# Altium schematic sheet cwh_psu.SchDoc
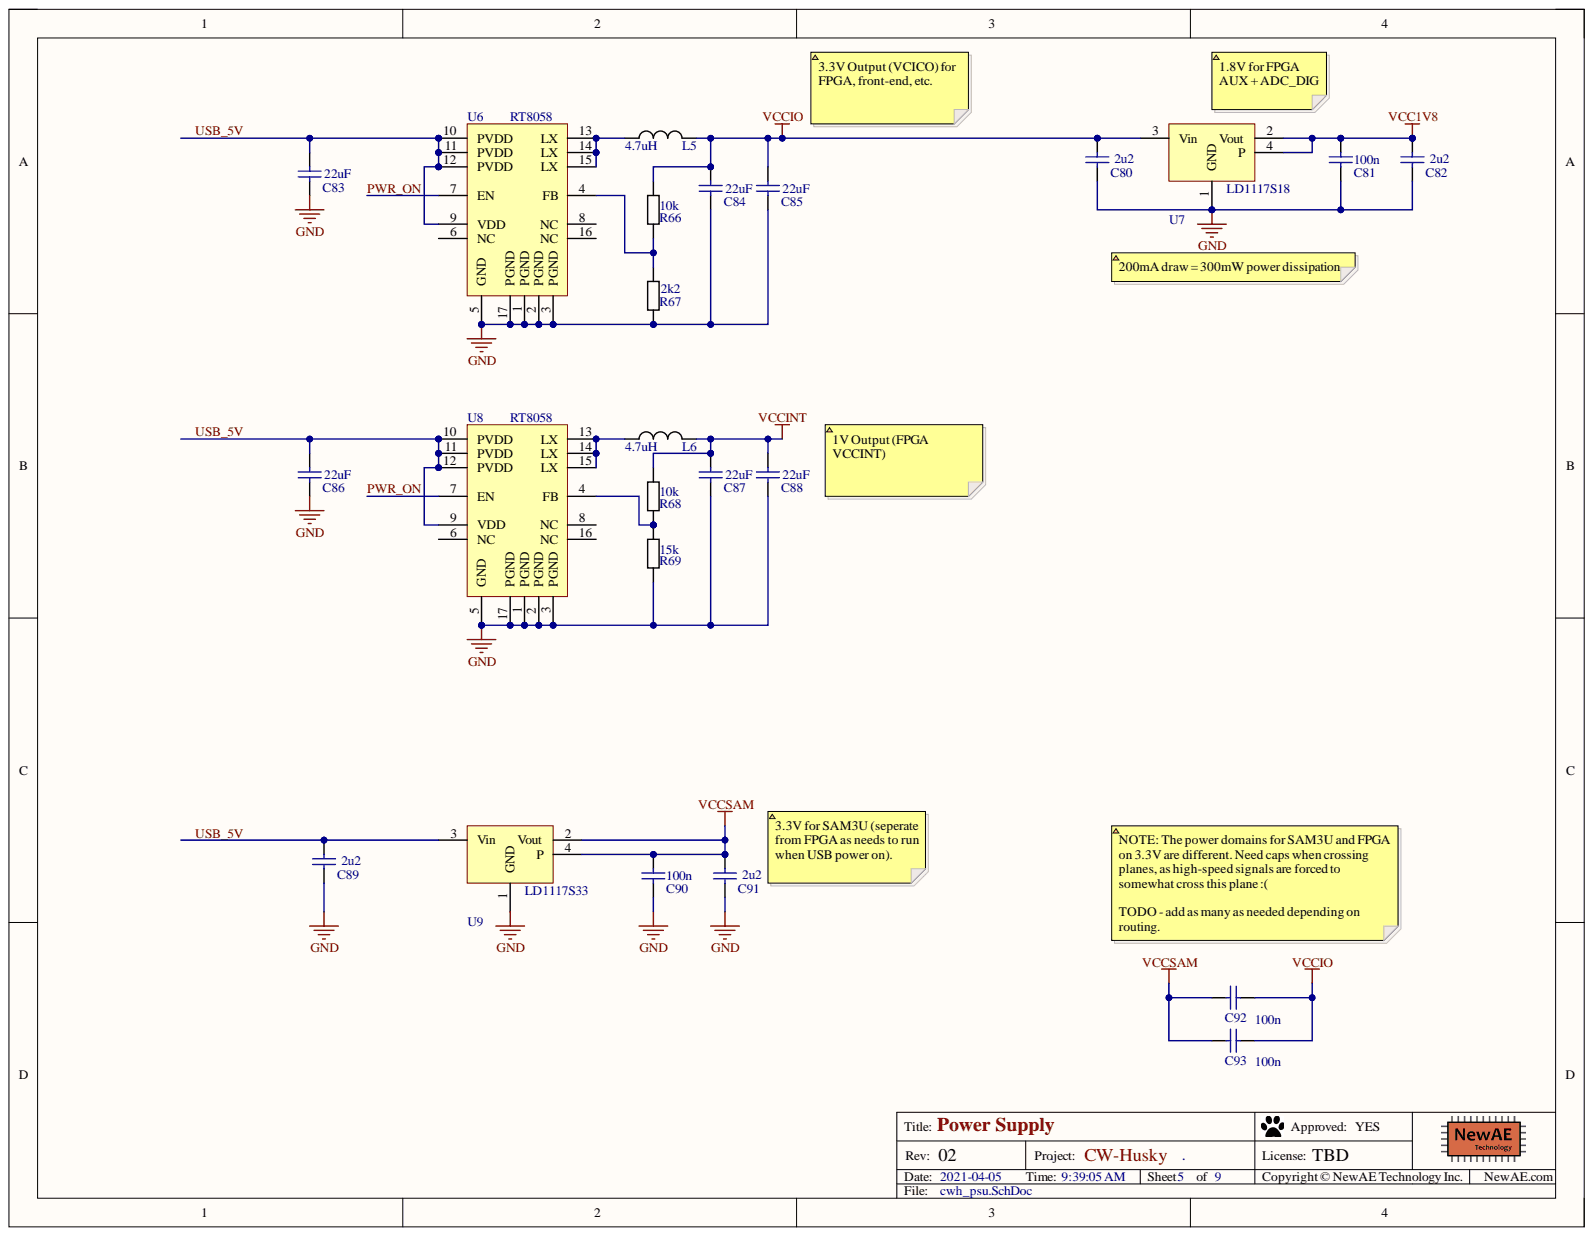
[diagram p0: cwh_psu.SchDoc]

## Parts
C80  2u2  [0603]
C81  100n  [0402]
C82  2u2  [0603]
C83  22uF  [0805]
C84  22uF  [0805]
C85  22uF  [0805]
C86  22uF  [0805]
C87  22uF  [0805]
C88  22uF  [0805]
C89  2u2  [0603]
C90  100n  [0402]
C91  2u2  [0603]
C92  100n  [0603]
C93  100n  [0603]
L5  4.7uH  [SMD,6x6mm]
L6  4.7uH  [SMD,6x6mm]
R66  10k  [0603]
R67  2k2  [0603]
R68  10k  [0603]
R69  15k  [0603]
U6  RT8058  [QFN16]
U7  LD1117S18  [SOT-223]
U8  RT8058  [QFN16]
U9  LD1117S33  [SOT-223]

## Nets
GND: C80.2 C81.2 C82.2 C83.2 C84.2 C85.2 C86.2 C87.2 C88.2 C89.2 C90.2 C91.2 R67.2 R69.2 U6.1(PGND) U6.2(PGND) U6.3(PGND) U6.5(GND) U6.17(PGND) U7.1(GND) U8.1(PGND) U8.2(PGND) U8.3(PGND) U8.5(GND) U8.17(PGND) U9.1(GND)
VCC1V8: C81.1 C82.1 U7.2(Vout) U7.4(P)
VCCINT: C87.1 C88.1 L6.2 R68.1
VCCIO: C80.1 C84.1 C85.1 C92.2 C93.2 L5.2 R66.1 U7.3(Vin)
VCCSAM: C90.1 C91.1 C92.1 C93.1 U9.2(Vout) U9.4(P)
PWR_ON: U6.7(EN) U8.7(EN)
USB_5V: C83.1 C86.1 C89.1 U6.9(VDD) U6.10(PVDD) U6.11(PVDD) U6.12(PVDD) U8.9(VDD) U8.10(PVDD) U8.11(PVDD) U8.12(PVDD) U9.3(Vin)
NetL5_1: L5.1 U6.13(LX) U6.14(LX) U6.15(LX)
NetL6_1: L6.1 U8.13(LX) U8.14(LX) U8.15(LX)
NetR66_2: R66.2 R67.1 U6.4(FB)
NetR68_2: R68.2 R69.1 U8.4(FB)

Not connected: U6 6(NC) 8(NC) 16(NC); U8 6(NC) 8(NC) 16(NC)

## Library data of the parts
C80 C82 C89 C91 = CCHIP-2u2-0603: 2u2, 0603; Manufacture 1: Samsung; Manufacture Part Number 1: CL10B225KP8NNNC; Case-Metric: 1608; Component Group: Capacitor; Database Table Name: main; Rated Voltage: 10VDC; Case-EIA: 0603; Component Kind: Standard; Component Type: Standard; Library Name: NewAE_AltiumLib.DBLib; Mounting Technology: SMT; Part Number: CCHIP-2u2-0603; Pin Count: 2; Supplier 1: Digi-Key; Supplier Part Number 1: 1276-1134-2-ND; Value: 2u2
C81 C90 = CCHIP-100n-0402: 100n, 0402; Component Group: Capacitor; Database Table Name: main; Case-EIA: 0402; Component Kind: Standard; Component Type: Standard; Library Name: NewAE_AltiumLib.DBLib; Mounting Technology: SMT; Part Number: CCHIP-100n-0402; Pin Count: 2; Supplier 1: Digi-Key; Supplier Part Number 1: 445-6901-1-ND; Value: 100n
C83-C88 = CCHIP-22u-0805-16V: 22u, 16V, 0805, Ceramic; Manufacture 1: Samsung Electro-Mechanics; Manufacture Part Number 1: CL21A226MOCLRNC; Case-Metric: 1608; Component Group: Capacitor; Database Table Name: main; Rated Voltage: 16VDC; Tolerance: 20%; Case-EIA: 0805; Component Kind: Standard; Component Type: Standard; Library Name: NewAE_AltiumLib.DBLib; Mounting Technology: SMT; Part Number: CCHIP-22u-0805-16V; Pin Count: 2; RoHS: Yes; Supplier 1: Digi-Key; Supplier Part Number 1: 1276-6780-1-ND; Value: 22uF
C92 C93 = CCHIP-100n-0603: 100n, 0603; Manufacture 1: Kemet; Manufacture Part Number 1: C0603C104K5RACTU; Case-Metric: 1608; Component Group: Capacitor; Database Table Name: main; Rated Voltage: 50VDC; Case-EIA: 0603; Component Kind: Standard; Component Type: Standard; Library Name: NewAE_AltiumLib.DBLib; Mounting Technology: SMT; Part Number: CCHIP-100n-0603; Pin Count: 2; Supplier 1: Digi-Key; Supplier Part Number 1: 399-5089-2-ND; Value: 100n
L5 L6 = NR6028T4R7M: fixed ind, ferrite, shielded; Manufacture 1: Taiyo Yuden; Case-Metric: 6060; Component Group: Inductor; Database Table Name: main; Component Kind: Standard; Component Type: Standard; Library Name: NewAE_AltiumLib.DBLib; Manufacture Part Number 1: NR6028T4R7M; Mounting Technology: SMT; Part Number: NR6028T4R7M; Pin Count: 2; Supplier 1: Digi-Key; Supplier Part Number 1: 587-2100-1-ND; Value: 4.7uH
R66 R68 = RCHIP-10K-0603: 10k res, 0603, 1%, 1/10W; Manufacture 1: Yageo; Manufacture Part Number 1: RC0603FR-0710KL; Case-Metric: 1608; Component Group: Resistor; Database Table Name: main; Rated Power: 0.1W; Rated Voltage: 75VDC; Case-EIA: 0603; Component Kind: Standard; Component Type: Standard; Library Name: NewAE_AltiumLib.DBLib; Mounting Technology: SMT; Part Number: RCHIP-10K-0603; Pin Count: 2; Supplier 1: Digi-Key; Supplier Part Number 1: 311-10.0KHRCT-ND; Temperature Range: -55C to 155C; Tolerance: 1%; Value: 10k
R67 = RCHIP-2K2-0603: 2k2 res, 0603, 1%, 1/10W; Manufacture 1: Yageo; Manufacture Part Number 1: RC0603FR-072K2L; Case-Metric: 1608; Component Group: Resistor; Database Table Name: main; Rated Power: 0.1W; Rated Voltage: 75VDC; Case-EIA: 0603; Component Kind: Standard; Component Type: Standard; Library Name: NewAE_AltiumLib.DBLib; Mounting Technology: SMT; Part Number: RCHIP-2K2-0603; Pin Count: 2; Supplier 1: Digi-Key; Supplier Part Number 1: 311-2.20KHRCT-ND; Temperature Range: -55C to 155C; Tolerance: 1%; Value: 2k2
R69 = RCHIP-15K-0603: 15k res, 0603, 1%, 1/10W; Manufacture 1: Yageo; Manufacture Part Number 1: RC0603FR-0715KL; Case-Metric: 1608; Component Group: Resistor; Database Table Name: main; Rated Power: 0.1W; Rated Voltage: 75VDC; Case-EIA: 0603; Component Kind: Standard; Component Type: Standard; Library Name: NewAE_AltiumLib.DBLib; Mounting Technology: SMT; Part Number: RCHIP-15K-0603; Pin Count: 2; Supplier 1: Digi-Key; Supplier Part Number 1: 311-15.0KHRCT-ND; Temperature Range: -55C to 155C; Tolerance: 1%; Value: 15k
U6 U8 = RT8058: Switching supply controller, 2A; Manufacture 1: Richtek; Component Group: Analog IC; Database Table Name: main; PP Material Stack: 0; Component Kind: Standard; Component Type: Standard; Library Name: NewAE_AltiumLib.DBLib; Manufacture Part Number 1: RT8058; Part Number: RT8058; Pin Count: 17; PP Rotation: 0
U7 = LD1117S18: IC REG LIN POS 1.8V 800MA SOT223; Manufacture 1: STMicroelectronics; Manufacture Part Number 1: LD1117S18TR; Component Group: Analog IC; Database Table Name: main; PP Material Stack: 0; Rated Voltage: 15V; Component Kind: Standard; Component Type: Standard; Library Name: NewAE_AltiumLib.DBLib; Mounting Technology: SMT; Part Number: LD1117S18; Pin Count: 3; PP Rotation: 0; RoHS: Yes; Supplier 1: Digi-Key; Supplier Part Number 1: 497-7308-1-ND
U9 = LD1117S33: IC REG LIN POS 3.3V 800MA SOT223; Manufacture 1: STMicroelectronics; Manufacture Part Number 1: LD1117S33TR; Component Group: Analog IC; Database Table Name: main; PP Material Stack: 0; Rated Voltage: 15V; Component Kind: Standard; Component Type: Standard; Library Name: NewAE_AltiumLib.DBLib; Mounting Technology: SMT; Part Number: LD1117S33; Pin Count: 3; PP Rotation: 0; RoHS: Yes; Supplier 1: Digi-Key; Supplier Part Number 1: 497-1242-1-ND
